# Altium schematic sheet Battery Management.SchDoc
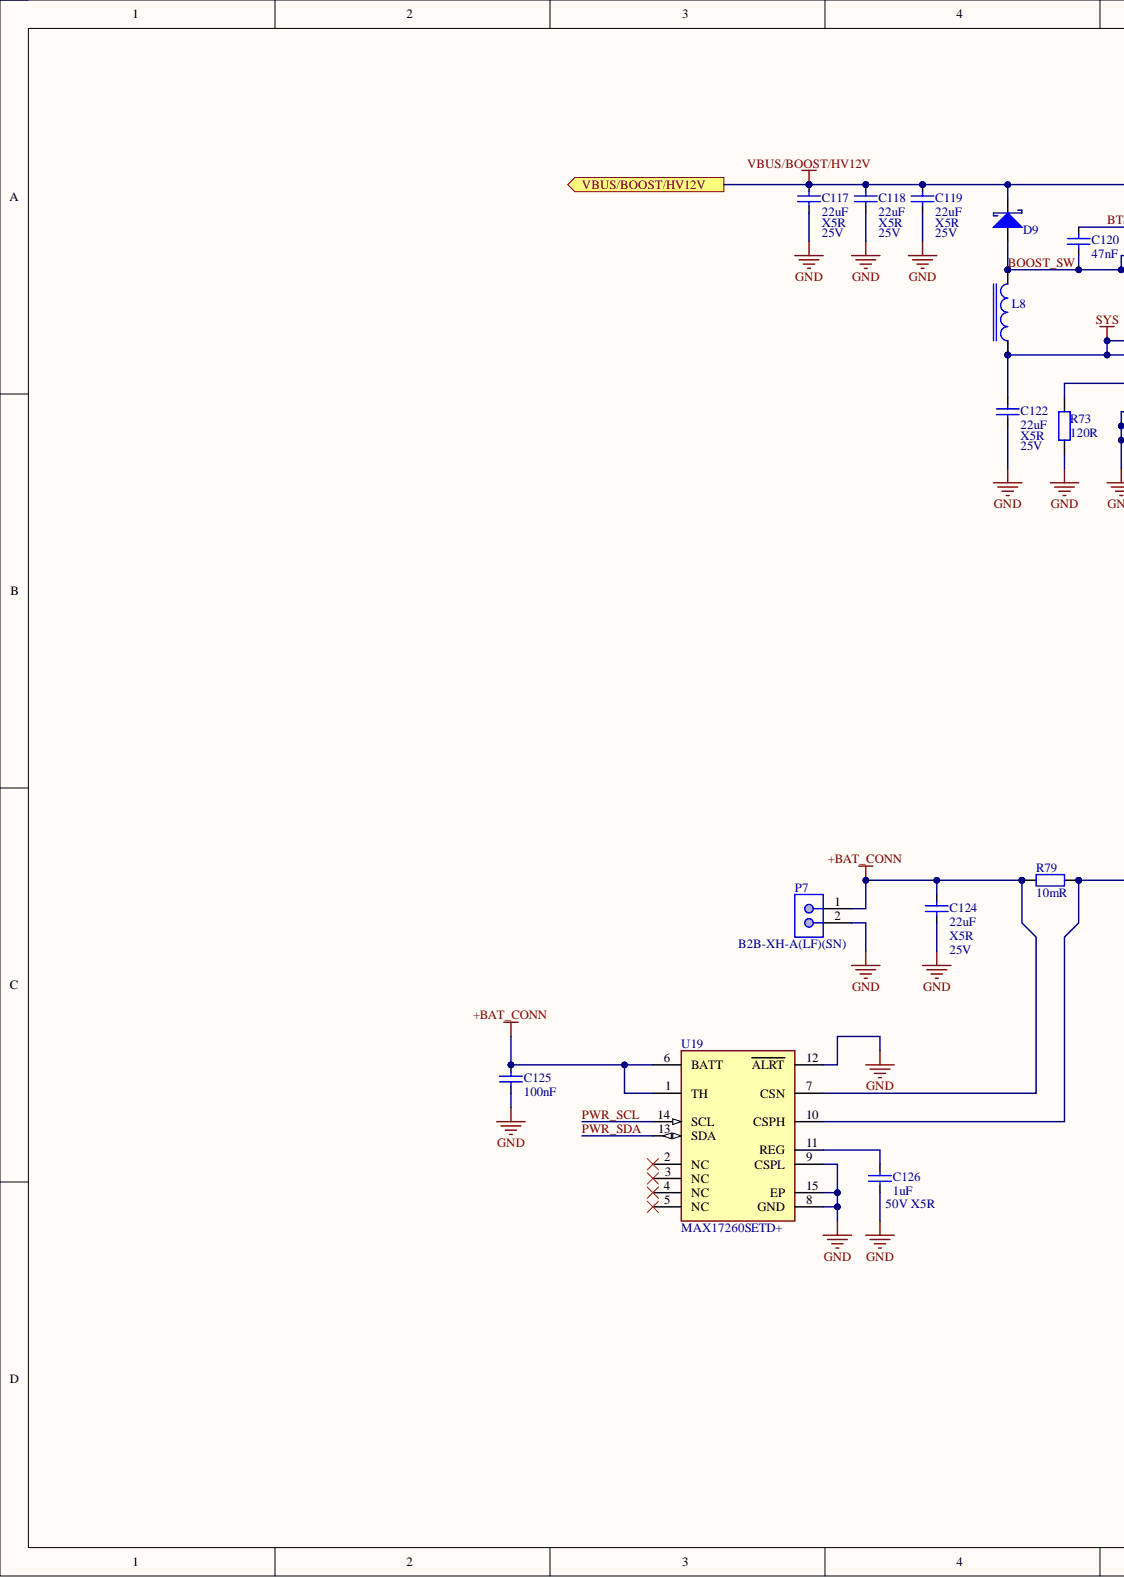
[diagram p0: Battery Management.SchDoc, left]
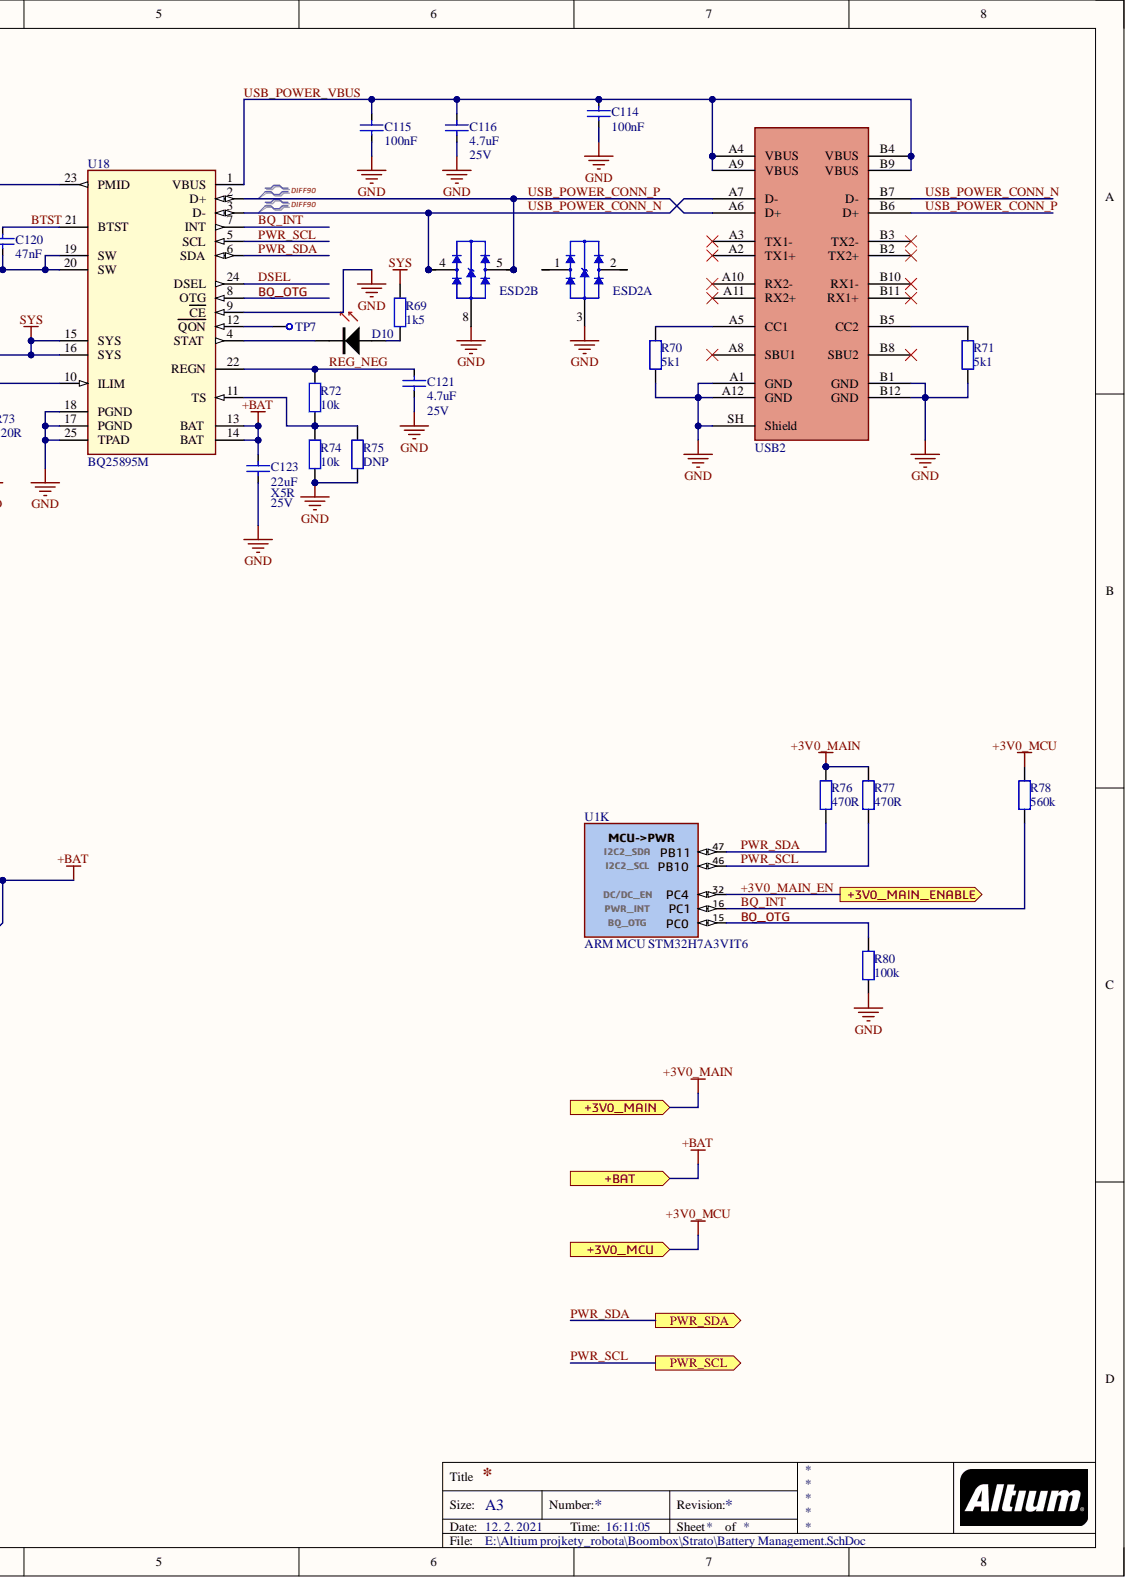
[diagram p1: Battery Management.SchDoc, right]

## Parts
C114  100nF  [CAPACITOR_0402_(1005)]
C115  100nF  [CAPACITOR_0402_(1005)]
C116  4.7uF  Voltage: 25V  [CAPACITOR_0603_(1608)]
C117  22uF  Dielectric: X5R  Voltage: 25V  [CAPACITOR_0805_(2012)]
C118  22uF  Dielectric: X5R  Voltage: 25V  [CAPACITOR_0805_(2012)]
C119  22uF  Dielectric: X5R  Voltage: 25V  [CAPACITOR_0805_(2012)]
C120  47nF  [CAPACITOR_0603_(1608)]
C121  4.7uF  Voltage: 25V  [CAPACITOR_0603_(1608)]
C122  22uF  Dielectric: X5R  Voltage: 25V  [CAPACITOR_0805_(2012)]
C123  22uF  Dielectric: X5R  Voltage: 25V  [CAPACITOR_0805_(2012)]
C124  22uF  Dielectric: X5R  Voltage: 25V  [CAPACITOR_0805_(2012)]
C125  100nF  [CAPACITOR_0402_(1005)]
C126  1uF  Dielectric: X5R  Voltage Rating: 50V X5R  Voltage Rating (DC): 50V  [CAPACITOR_0603_(1608)]
D9  NSR10F30NXT5G  [D_DSN2_(0502)]  (value not drawn)
D10  LED RED  [LED_0805_RED]  (value not drawn)
ESD2  ESD Suppressor  [DQA10]  (value not drawn)  (parts A,B)
L8  SRP7030CA-2R2M  [Power Inductor_SRP7030CA(SMD)  Bourns - Edited]  (value not drawn)
P7  B2B-XH-A(LF)(SN)  [JST-B2B-XH-A_V]
R69  1k5  [RESISTOR_0603_(1608)]
R70  5k1  [RESISTOR_0603_(1608)]
R71  5k1  [RESISTOR_0603_(1608)]
R72  10k  [RESISTOR_0603_(1608)]
R73  120R  [RESISTOR_0603_(1608)]
R74  10k  [RESISTOR_0603_(1608)]
R75  DNP  [RESISTOR_0603_(1608)]  (no BOM)
R76  470R  [RESISTOR_0603_(1608)]
R77  470R  [RESISTOR_0603_(1608)]
R78  560k  [RESISTOR_0603_(1608)]
R79  10mR  [RESISTOR_1206_(3216)]
R80  100k  [RESISTOR_0603_(1608)]
TP7  test point  [TP]  (value not drawn)  (no BOM)
U1  ARM MCU STM32H7A3VIT6  Manufacturer Part Number: STM32H7A3VIT6  [STM-LQFP100_N - Edited]  (part K)
U18  BQ25895M  [S-PWQFN-N24]
U19  MAX17260SETD+  [TDFN-EP-14]
USB2  DX07W024JA1R1000  [USB Type C DX07W024JA1]  (value not drawn)

## Ports
+3V0_MAIN, +3V0_MAIN_ENABLE, +3V0_MCU, +BAT, PWR_SCL, PWR_SDA, VBUS/BOOST/HV12V

## Nets
+BAT_CONN: C124.2 C125.1 P7.1 R79.2 U19.1(TH) U19.6(BATT) U19.7(CSN)
GND: C114.2 C115.2 C116.2 C117.1 C118.1 C119.1 C121.2 C122.1 C123.1 C124.1 C125.2 C126.2 ESD2.3 ESD2.8 P7.2 R70.2 R71.2 R73.1 R74.1 R75.1 R80.1 U18.9(CE) U18.17(PGND) U18.18(PGND) U18.25(TPAD) U19.8(GND) U19.9(CSPL) U19.12(ALRT) U19.15(EP) USB2.A1(GND) USB2.A12(GND) USB2.B1(GND) USB2.B12(GND) USB2.SH(Shield)
SYS: C122.2 L8.1 R69.1 U18.15(SYS) U18.16(SYS)
+3V0_MAIN: R76.2 R77.2 ; port +3V0_MAIN
+3V0_MAIN_EN: U1.32(PC4) ; port +3V0_MAIN_ENABLE
+3V0_MCU: R78.2 ; port +3V0_MCU
+BAT: C123.2 R79.1 U18.13(BAT) U18.14(BAT) U19.10(CSPH) ; port +BAT
BOOST_SW: C120.1 D9.2 L8.2 U18.19(SW) U18.20(SW)
BQ_INT: R78.1 U1.16(PC1) U18.7(INT)
BQ_OTG: R80.2 U1.15(PC0) U18.8(OTG)
BTST: C120.2 U18.21(BTST)
DSEL: U18.24(DSEL)
PWR_SCL: R77.1 U1.46(PB10) U18.5(SCL) U19.14(SCL) ; port PWR_SCL
PWR_SDA: R76.1 U1.47(PB11) U18.6(SDA) U19.13(SDA) ; port PWR_SDA
REG_NEG: C121.1 R72.2 U18.22(REGN)
USB_POWER_CONN_N: ESD2.4 ESD2.7 U18.3(D-) USB2.A7(D-) USB2.B7(D-)
USB_POWER_CONN_P: ESD2.5 ESD2.6 U18.2(D+) USB2.A6(D+) USB2.B6(D+)
USB_POWER_VBUS: C114.1 C115.1 C116.1 U18.1(VBUS) USB2.A4(VBUS) USB2.A9(VBUS) USB2.B4(VBUS) USB2.B9(VBUS)
VBUS/BOOST/HV12V: C117.2 C118.2 C119.2 D9.1 U18.23(PMID) ; port VBUS/BOOST/HV12V
NetC126_1: C126.1 U19.11(REG)
NetD10_A: D10.A R69.2
NetD10_K: D10.K U18.4(STAT)
NetESD2_1: ESD2.1 ESD2.10
NetESD2_2: ESD2.2 ESD2.9
NetR70_1: R70.1 USB2.A5(CC1)
NetR71_1: R71.1 USB2.B5(CC2)
NetR72_1: R72.1 R74.2 R75.2 U18.11(TS)
NetR73_2: R73.2 U18.10(ILIM)
NetTP7_1: TP7.1 U18.12(QON)

Not connected: U19 2(NC) 3(NC) 4(NC) 5(NC); USB2 A2(TX1+) A3(TX1-) A8(SBU1) A10(RX2-) A11(RX2+) B2(TX2+) B3(TX2-) B8(SBU2) B10(RX1-) B11(RX1+)

## Library data of the parts
C114 C115 C120 C125 = CAPACITOR: ESR: 0.28R; Voltage Rating: 10V; Component Kind: Standard; Description: CAPACITOR; Library Name: RLCFB.SchLib
  C114 C115 C125: Capacitance: 100nF
  C120: Capacitance: 47nF
C116 C121 = CAPACITOR: Manufacturer: Murata; Manufacturer Part Number 1: GRM188R61E475KE11D; Dielectric: X5R; ESR: 0.28R; Material: Ceramic; Tolerance: 10%; Capacitance: 4.7uF; Case Code (Imperial): 0603; Case Code (Metric): 1608; Case/Package: 0603; Component Kind: Standard; ComponentLink1Description: https://datasheet.ciiva.com/46444/1769893-46444982.pdf?src-supplier=Element14; ComponentLink1URL: https://datasheet.ciiva.com/46444/1769893-46444982.pdf?src-supplier=Element14; ComponentLink2Description: https://datasheet.ciiva.com/46444/1769893-46444983.pdf?src-supplier=Element14; ComponentLink2URL: https://datasheet.ciiva.com/46444/1769893-46444983.pdf?src-supplier=Element14; ComponentLink3Description: https://datasheet.ciiva.com/14891/partnumbering-e-01-14891102.pdf?src-supplier=Digi-Key; ComponentLink3URL: https://datasheet.ciiva.com/14891/partnumbering-e-01-14891102.pdf?src-supplier=Digi-Key; ComponentLink4Description: https://datasheet.ciiva.com/14891/partnumbering-e-01-14891103.pdf?src-supplier=Digi-Key; ComponentLink4URL: https://datasheet.ciiva.com/14891/partnumbering-e-01-14891103.pdf?src-supplier=Digi-Key; Depth: 0.8mm; Description: CAPACITOR; Height: 0.8mm; Lead Free: Lead Free; Length: 1.6mm; Max Operating Temperature: 85°C; Min Operating Temperature: -55°C; Mount: Surface Mount; Package Quantity: 4000; Packaging: Cut Tape; Pricing 1: 10=0,35700, 100=100,00000, 500=0,15900, 1000=0,12800, 2000=0,10200 (CHF); REACH SVHC: No SVHC; RoHS: Yes; Stock 1: 95119; Supplier 1: Farnell; Supplier Part Number 1: 2426959; Thickness: 0.031inch; Voltage Rating: 25V; Voltage Rating (DC): 25V; Width: 0.031inch; Library Name: RLCFB.SchLib
C117-C119 C122-C124 = CAPACITOR: Manufacturer: Murata; Manufacturer Part Number 1: GRM21BR61E226ME44L; Material: Ceramic; Tolerance: 20%; Capacitance: 22uF; Case Code (Imperial): 0805; Case Code (Metric): 2012; Case/Package: 0805; Component Kind: Standard; ComponentLink1Description: https://datasheet.ciiva.com/22787/1747471-22787265.pdf?src-supplier=Farnell; ComponentLink1URL: https://datasheet.ciiva.com/22787/1747471-22787265.pdf?src-supplier=Farnell; ComponentLink2Description: https://datasheet.ciiva.com/7719/1747471-7719095.pdf?src-supplier=Element14; ComponentLink2URL: https://datasheet.ciiva.com/7719/1747471-7719095.pdf?src-supplier=Element14; ComponentLink3Description: https://datasheet.ciiva.com/28584/1747471-28584199.pdf?src-supplier=Element14; ComponentLink3URL: https://datasheet.ciiva.com/28584/1747471-28584199.pdf?src-supplier=Element14; Composition: Ceramic; Depth: 1.25mm; Description: CAPACITOR; Height: 1.25mm; Lead Free: Lead Free; Length: 2mm; Max Operating Temperature: 85°C; Min Operating Temperature: -55°C; Mount: Surface Mount; Package Quantity: 3000; Packaging: Tape and Reel; Pricing 1: 5=0,42800, 50=0,25100, 250=0,20300, 500=0,15000, 1500=0,12800 (EUR); REACH SVHC: No SVHC; RoHS: Yes; Stock 1: 70400; Supplier 1: Farnell; Supplier Part Number 1: 1907510; Termination: SMD/SMT; Thickness: 0.057inch; Voltage Rating: 25V; Voltage Rating (DC): 25V; Weight: 0.000335oz; Width: 1.25mm; Library Name: RLCFB.SchLib
C126 = CAPACITOR: Manufacturer: Taiyo Yuden; Manufacturer Part Number 1: UMK107BJ105KA-T; ESR: 0.28R; Tolerance: 10%; Capacitance: 1uF; Case Code (Imperial): 0603; Case Code (Metric): 1608; Case/Package: 0603; Component Kind: Standard; ComponentLink1Description: https://datasheet.ciiva.com/5744/1697715-5744913.pdf?src-supplier=Farnell; ComponentLink1URL: https://datasheet.ciiva.com/5744/1697715-5744913.pdf?src-supplier=Farnell; ComponentLink2Description: https://datasheet.ciiva.com/11601/umk107bj105ka-t-ss-11601811.pdf?src-supplier=Avnet; ComponentLink2URL: https://datasheet.ciiva.com/11601/umk107bj105ka-t-ss-11601811.pdf?src-supplier=Avnet; ComponentLink3Description: https://datasheet.ciiva.com/15922/336umk107bj105ka-t-15922611.pdf?src-supplier=Onlinecomponents.com; ComponentLink3URL: https://datasheet.ciiva.com/15922/336umk107bj105ka-t-15922611.pdf?src-supplier=Onlinecomponents.com; ComponentLink4Description: https://datasheet.ciiva.com/134/umk107bj105ka-t-ss-134740.pdf?src-supplier=Digi-Key; ComponentLink4URL: https://datasheet.ciiva.com/134/umk107bj105ka-t-ss-134740.pdf?src-supplier=Digi-Key; ComponentLink5Description: https://datasheet.ciiva.com/30199/43umk107bj105ka-t-30199791.pdf?src-supplier=Onlinecomponents.com; ComponentLink5URL: https://datasheet.ciiva.com/30199/43umk107bj105ka-t-30199791.pdf?src-supplier=Onlinecomponents.com; ComponentLink6Description: https://datasheet.ciiva.com/22694/1697715-22694278.pdf?src-supplier=Element14; ComponentLink6URL: https://datasheet.ciiva.com/22694/1697715-22694278.pdf?src-supplier=Element14; ComponentLink7Description: https://datasheet.ciiva.com/7975/1697715-7975608.pdf?src-supplier=Element14; ComponentLink7URL: https://datasheet.ciiva.com/7975/1697715-7975608.pdf?src-supplier=Element14; ComponentLink8Description: https://datasheet.ciiva.com/7975/mlcc-all-e-7975898.pdf?src-supplier=Element14; ComponentLink8URL: https://datasheet.ciiva.com/7975/mlcc-all-e-7975898.pdf?src-supplier=Element14; ComponentLink9Description: https://datasheet.ciiva.com/5427/mlcc-all-e-541559-5427036.pdf?src-supplier=Mouser; ComponentLink9URL: https://datasheet.ciiva.com/5427/mlcc-all-e-541559-5427036.pdf?src-supplier=Mouser; Contact Plating: Nickel; Depth: 0.8mm; Description: CAPACITOR; Halogen Free: Halogen Free; Height: 0.8mm; Length: 1.6mm; Max Operating Temperature: 85°C; Min Operating Temperature: -55°C; Mount: Surface Mount; Number of Pins: 2; Package Quantity: 1; Packaging: Tape and Reel; Pricing 1: 10=0,14300, 100=0,06560, 500=0,04260, 1000=0,03940, 2000=0,03750, 4000=0,02830, 20000=0,02630 (EUR); Radiation Hardening: No; REACH SVHC: Unknown; RoHS: Yes; Stock 1: 372983; Supplier 1: Farnell; Supplier Part Number 1: 2112858; Termination: SMD/SMT; Thickness: 0.035inch; Width: 0.031inch; Library Name: RLCFB.SchLib
D9 = D_NSR10F30NXT5G: DIODE SCHOTTKY 30V 1A 2DSN; Manufacturer: ON Semiconductor; Element Configuration: Single; Forward Voltage: 470mV; Max Forward Surge Current (Ifsm): 18A; Max Power Dissipation: 1.47W; Max Reverse Current: 100uA; Max Reverse Leakage Current: 20uA; Power Dissipation: 548mW; Average Rectified Current: 1A; Case/Package: 0502; Category: Schottky Diodes; Component Kind: Standard; ComponentLink1Description: https://datasheet.ciiva.com/917/nsr10f30-d-917057.pdf?src-supplier=Digi-Key; ComponentLink1URL: https://datasheet.ciiva.com/917/nsr10f30-d-917057.pdf?src-supplier=Digi-Key; ComponentLink2Description: https://datasheet.ciiva.com/4713/nsr10f30-97625-4713884.pdf?src-supplier=Mouser; ComponentLink2URL: https://datasheet.ciiva.com/4713/nsr10f30-97625-4713884.pdf?src-supplier=Mouser; ComponentLink3Description: https://datasheet.ciiva.com/917/nsr10f30-d-917058.pdf?src-supplier=Digi-Key; ComponentLink3URL: https://datasheet.ciiva.com/917/nsr10f30-d-917058.pdf?src-supplier=Digi-Key; ComponentLink4Description: https://datasheet.ciiva.com/917/nsr10f30-d-917059.pdf?src-supplier=Digi-Key; ComponentLink4URL: https://datasheet.ciiva.com/917/nsr10f30-d-917059.pdf?src-supplier=Digi-Key; ComponentLink5Description: https://datasheet.ciiva.com/16474/nsr10f30-d-16474534.pdf?src-supplier=Future+Electronics; ComponentLink5URL: https://datasheet.ciiva.com/16474/nsr10f30-d-16474534.pdf?src-supplier=Future+Electronics; Forward Current: 1A; Lead Free: Lead Free; Library Name: BB1_SCH_Library.SchLib; Manufacturer Part Number: NSR10F30NXT5G; Max Operating Temperature: 150°C; Max Repetitive Reverse Voltage (Vrrm): 30V; Max Reverse Voltage (DC): 30V; Max Surge Current: 18A; Min Operating Temperature: -40°C; Number of Pins: 2; Package Quantity: 5000; Packaging: Tape and Reel; Peak Non-Repetitive Surge Current: 18A; Pricing 1: 1=0,44000, 10=0,32200, 100=0,18700, 1000=0,12200, 5000=0,11200, 10000=0,10300 (USD); Radiation Hardening: No; RoHS: Yes; Stock 1: 10180; Supplier 1: Mouser; Supplier Part Number 1: 863-NSR10F30NXT5G
D10 = LED RED 0805: KPT-2012EC -  LED, Low Power, Red, SMD, 0805, 2 mA, 2 V, 617 nm; Manufacturer: Kingbright; Dominant Wavelength: 617nm; Forward Current: 20mA; Forward Voltage: 2V; Lens Color: Clear; Lens Style: Diffused; Luminous Intensity: 8mcd; Number of LEDs: 1; Viewing Angle: 120°; Case/Package: 2012; Color: Red; Component Kind: Standard; ComponentLink1Description: https://datasheet.ciiva.com/8060/1563702-8060626.pdf?src-supplier=Element14; ComponentLink1URL: https://datasheet.ciiva.com/8060/1563702-8060626.pdf?src-supplier=Element14; Library Name: Source.SchLib; Manufacturer Part Number 1: KPT-2012EC; Mount: Surface Mount; Number of Pins: 2; Packaging: Cut Tape; Pricing 1: 5=0,15600, 50=0,14200, 100=0,09300, 500=0,07860, 1000=0,06180 (EUR); Radiation Hardening: No; REACH SVHC: No SVHC; RoHS: Yes; Stock 1: 30789; Supplier 1: Farnell; Supplier Part Number 1: 2099236; Wavelength: 617nm
ESD2 = D_TPD4E05U06DQAR: TEXAS INSTRUMENTS - ESD Protection Device, 14 V, USON, 10 Pins, 5.5 V, TPD4E Series; Breakdown Voltage: 6.5V; Capacitance: 0.5pF; Clamping Voltage: 14V; Direction: Unidirectional; Interface: LVDS; Leakage Current: 10nA; Max Power Dissipation: 40W; Number of Bidirectional Channels: 4; Operating Supply Voltage: 5.5V; Peak Pulse Current: 5A; Power Line Protection: Yes; Component Kind: Standard; ComponentLink1Description: https://datasheet.ciiva.com/15063/tpd4e05u06-15063653.pdf?src-supplier=Digi-Key; ComponentLink1URL: https://datasheet.ciiva.com/15063/tpd4e05u06-15063653.pdf?src-supplier=Digi-Key; ComponentLink2Description: https://datasheet.ciiva.com/26918/getdatasheetpartid-716763-26918024.pdf?src-supplier=Verical; ComponentLink2URL: https://datasheet.ciiva.com/26918/getdatasheetpartid-716763-26918024.pdf?src-supplier=Verical; ComponentLink3Description: https://datasheet.ciiva.com/24119/slvsbo7f-316827-24119436.pdf?src-supplier=Mouser; ComponentLink3URL: https://datasheet.ciiva.com/24119/slvsbo7f-316827-24119436.pdf?src-supplier=Mouser; ComponentLink4Description: https://datasheet.ciiva.com/15063/tpd4e05u06-15063654.pdf?src-supplier=Digi-Key; ComponentLink4URL: https://datasheet.ciiva.com/15063/tpd4e05u06-15063654.pdf?src-supplier=Digi-Key; ComponentLink5Description: https://datasheet.ciiva.com/29883/tpd4e05u06-29883798.pdf?src-supplier=Avnet; ComponentLink5URL: https://datasheet.ciiva.com/29883/tpd4e05u06-29883798.pdf?src-supplier=Avnet; ComponentLink6Description: https://datasheet.ciiva.com/15063/tpd4e05u06-15063655.pdf?src-supplier=Digi-Key; ComponentLink6URL: https://datasheet.ciiva.com/15063/tpd4e05u06-15063655.pdf?src-supplier=Digi-Key; Contact Plating: Gold; Depth: 1.1mm; Lead Free: Lead Free; Length: 2.6mm; Library Name: Source.SchLib; Manufacturer: Texas Instruments; Manufacturer Part Number 1: TPD4E05U06DQAR; Max Operating Temperature: 125°C; Min Breakdown Voltage: 6.5V; Min Operating Temperature: -40°C; Mount: Surface Mount; Number of Channels: 4; Number of Pins: 10; Package Quantity: 3000; Packaging: Tape and Reel; Peak Pulse Power: 40W; Polarity: Unidirectional; Pricing 1: 1=0,61000, 10=0,50000, 50=0,50000, 100=0,32400, 1000=0,26000, 2000=0,23500, 3000=0,21900, 9000=0,21100, 10000=0,21100, 24000=0,20300, 48000=0,19500 (USD); Radiation Hardening: No; REACH SVHC: No SVHC; Reverse Standoff Voltage: 5.5V; RoHS: Yes; Stock 1: 7182; Supplier 1: Mouser; Supplier Part Number 1: 595-TPD4E05U06DQAR; Termination: SMD/SMT; Working Voltage: 5.5V
L8 = IND_SRP7030CA-2R2M: Fixed Inductors Ind,7.8x7.6x2.9mm,2.2uH 20%,13A,Shd,SMD; Manufacturer: Bourns; Component Kind: Standard; Library Name: BB1_SCH_Library.SchLib; Manufacturer Part Number: SRP7030CA-2R2M; Pricing 1: 1=1,53000, 10=1,48000, 25=1,43000, 50=1,39000, 100=1,35000, 250=1,16000 (USD); RoHS: No; Stock 1: 197; Supplier 1: Mouser; Supplier Part Number 1: 652-SRP7030CA-2R2M
P7 = Batt Connector B2B-XH-A: Conn Shrouded Header (4 Sides) HDR 2 POS 2.5mm Solder ST Thru-Hole Box; Manufacturer: JST; Connector Type: Male Pin; Contact Resistance: 20mR; Current Rating: 3A; Insulation Resistance: 1GR; Max Voltage Rating (AC): 250V; Number of Contacts: 2; Wire/Cable Gauge: 22AWG; Category: FFC / FPC; Color: Natural; Component Kind: Standard; ComponentLink1Description: https://datasheet.ciiva.com/26894/getdatasheetpartid-640357-26894434.pdf?src-supplier=Verical; ComponentLink1URL: https://datasheet.ciiva.com/26894/getdatasheetpartid-640357-26894434.pdf?src-supplier=Verical; ComponentLink2Description: https://datasheet.ciiva.com/11746/0900766b8082653e-11746979.pdf?src-supplier=RSComponents; ComponentLink2URL: https://datasheet.ciiva.com/11746/0900766b8082653e-11746979.pdf?src-supplier=RSComponents; ComponentLink3Description: https://datasheet.ciiva.com/26894/getdatasheetpartid-385291-26894760.pdf?src-supplier=Verical; ComponentLink3URL: https://datasheet.ciiva.com/26894/getdatasheetpartid-385291-26894760.pdf?src-supplier=Verical; ComponentLink4Description: https://datasheet.ciiva.com/8052/5448-8052024.pdf?src-supplier=Element14; ComponentLink4URL: https://datasheet.ciiva.com/8052/5448-8052024.pdf?src-supplier=Element14; ComponentLink5Description: https://datasheet.ciiva.com/20207/523653-20207167.pdf?src-supplier=Rapid+Electronics; ComponentLink5URL: https://datasheet.ciiva.com/20207/523653-20207167.pdf?src-supplier=Rapid+Electronics; Contact Material: Brass; Contact Plating: Tin,Copper,Lead; Depth: 5.75mm; Fastening Type: Detent; Housing Color: White; Housing Material: Polyamide; Lead Free: Lead Free; Lead Pitch: 2.5mm; Length: 7.4mm; Library Name: BB1_SCH_Library.SchLib; Manufacturer Part Number: B2B-XH-A(LF)(SN); Max Current Rating: 3A; Max Operating Temperature: 85°C; Max Voltage Rating (DC): 250V; Min Operating Temperature: -25°C; Mount: Through Hole; Number of Positions: 2; Number of Rows: 1; Orientation: Straight; Packaging: Bulk; Pitch: 2.5mm; Pricing 1: 100=0,06890, 1000=0,06030, 2500=0,05600, 5000=0,05160, 10000=0,05030, 20000=0,04930 (EUR); REACH SVHC: No SVHC; RoHS: Yes; Stock 1: 67303; Supplier 1: Farnell; Supplier Part Number 1: 1516276; Termination: Solder; Voltage Rating: 250V; Voltage Rating (AC): 250V
R69-R78 R80 = RESISTOR: Power Rating: 500mW; Description: RESISTOR; Library Name: RLCFB.SchLib
  R69: Component Kind: Standard; Resistance: 1k5
  R70 R71: Component Kind: Standard; Resistance: 5k1
  R72 R74: Component Kind: Standard; Resistance: 10k
  R73: Component Kind: Standard; Resistance: 120R
  R75: Resistance: DNP
  R76 R77: Component Kind: Standard; Resistance: 470R
  R78: Component Kind: Standard; Resistance: 560k
  R80: Component Kind: Standard; Resistance: 100k
R79 = RESISTOR: Manufacturer: TT Welwyn; Manufacturer Part Number: LRMAM1206-R01FT5; Max Power Dissipation: 1W; Temperature Coefficient: 100ppm/°C; Tolerance: 1%; Case Code (Imperial): 1206; Case Code (Metric): 3216; Case/Package: 1206; Component Kind: Standard; ComponentLink1Description: https://datasheet.ciiva.com/7932/1518406-7932008.pdf?src-supplier=Element14; ComponentLink1URL: https://datasheet.ciiva.com/7932/1518406-7932008.pdf?src-supplier=Element14; ComponentLink2Description: https://datasheet.ciiva.com/14982/lrma-14982158.pdf?src-supplier=Digi-Key; ComponentLink2URL: https://datasheet.ciiva.com/14982/lrma-14982158.pdf?src-supplier=Digi-Key; ComponentLink3Description: https://datasheet.ciiva.com/14982/lrma-14982159.pdf?src-supplier=Digi-Key; ComponentLink3URL: https://datasheet.ciiva.com/14982/lrma-14982159.pdf?src-supplier=Digi-Key; Composition: Metal Element; Depth: 1.6mm; Description: RESISTOR; Features: Automotive AEC-Q200; Height: 0.031inch; Length: 3.2mm; Max Operating Temperature: 170°C; Military Standard: Not; Min Operating Temperature: -55°C; Number of Terminations: 2; Package Quantity: 5000; Packaging: Tape and Reel; Power Rating: 1W; Pricing 1: 10=0,32600, 100=0,16300, 500=0,13200, 1000=0,12600, 2500=0,12000 (EUR); REACH SVHC: No SVHC; Resistance: 10mR; RoHS: Yes; Stock 1: 775; Supplier 1: Farnell; Supplier Part Number 1: 2079442; Termination: SMD/SMT; Width: 0.063inch; Library Name: RLCFB.SchLib
U1 = STM32H7A3VIT6_LQFP100: STM32H7A3VIT6 -  32-bit Arm® Cortex®-M7 280 MHz MCUs, up to 2-Mbyte Flash memory, 1.4Mbyte RAM, 46 com. and analog interfaces; Manufacturer: STMicroelectronics; Category: Microcontrollers; Component Kind: Standard; ComponentLink1Description: Datasheet; ComponentLink1URL: https://www.st.com/resource/en/datasheet/stm32h7a3vi.pdf; Library Name: BB1_SCH_Library.SchLib; RoHS: No; Supplier 1: Mouser; Supplier Part Number 1: 511-STM32H7A3VIT6
U18 = BQ25895M: IC NVDC CHARGER I2C 24WQFN; Manufacturer: Texas Instruments; Manufacturer Part Number: BQ25895MRTWR; Fault Protection: Over Temperature; Interface: I2C,USB; Max Charge Current: 5A; Max Supply Voltage: 14V; Number of Cells: 1; Output Voltage: 5.5V; Category: Battery Management; Component Kind: Standard; ComponentLink1Description: http://www.ti.com/lit/ds/symlink/bq25895m.pdf?src-supplier=Digi-Key; ComponentLink1URL: http://www.ti.com/lit/ds/symlink/bq25895m.pdf?src-supplier=Digi-Key; ComponentLink2Description: http://www.ti.com/general/docs/lit/getliterature.tsp?genericPartNumber=BQ25895M&amp;&amp;fileType=pdf&src-supplier=Avnet+Asia; ComponentLink2URL: http://www.ti.com/general/docs/lit/getliterature.tsp?genericPartNumber=BQ25895M&amp;&amp;fileType=pdf&src-supplier=Avnet+Asia; ComponentLink3Description: http://www.ti.com/general/docs/lit/getliterature.tsp?genericPartNumber=BQ25895M&&fileType=pdf&src-supplier=Avnet; ComponentLink3URL: http://www.ti.com/general/docs/lit/getliterature.tsp?genericPartNumber=BQ25895M&&fileType=pdf&src-supplier=Avnet; Contact Plating: Gold; Lead Free: Lead Free; Library Name: BB1_SCH_Library.SchLib; Max Operating Temperature: 85°C; Min Operating Temperature: -40°C; Mount: Surface Mount; Number of Pins: 24; Output Current: 5A; Packaging: Cut Tape; Pricing 1: 1=3,27000, 10=2,94000, 25=2,78000, 100=2,28000, 250=2,05000, 500=1,98000 (USD); RoHS: Yes; Stock 1: 3332; Supplier 1: Mouser; Supplier Part Number 1: 595-BQ25895MRTWR
U19 = Battery Fuel Gauge MAX17260: Fuel Gauge Li+/LiFePO4 1-Cell 2-Wire Interface 5.1uA 0.4V 14-Pin TDFN-EP Tube; Manufacturer: Maxim; Category: Battery Management; Component Kind: Standard; Library Name: BB1_SCH_Library.SchLib; Manufacturer Part Number: MAX17260SETD+; Pricing 1: 1=2,28000, 10=2,21000, 25=1,53000, 50=1,36000, 100=1,32000, 250=1,28000 (USD); RoHS: No; Stock 1: 2571; Supplier 1: Mouser; Supplier Part Number 1: 700-MAX17260SETD+
USB2 = USB C JAE: CONN RCPT USB3.1 TYPEC 24POS SMD; Manufacturer: JAE Electronics; Connector Type: USB; Current Rating: 6.25A; Gender: Receptacle; Mating Cycles: 10000; Number of Contacts: 24; Number of Ports: 1; Ratings: Waterproof; Shielding: Shielded; Category: Connectors; Component Kind: Standard; ComponentLink1Description: http://www.jae.com/z-en/pdf_download_exec.cfm?param=SJ119582.pdf&src-supplier=Digi-Key; ComponentLink1URL: http://www.jae.com/z-en/pdf_download_exec.cfm?param=SJ119582.pdf&src-supplier=Digi-Key; ComponentLink2Description: http://www.jae.com/z-en/pdf_download_exec.cfm?param=SJ119583.pdf&src-supplier=Digi-Key; ComponentLink2URL: http://www.jae.com/z-en/pdf_download_exec.cfm?param=SJ119583.pdf&src-supplier=Digi-Key; Contact Plating: Gold; Insulation Color: Black; Library Name: BB1_SCH_Library.SchLib; Manufacturer Part Number: DX07W024JA1R1000; Max Operating Temperature: 80°C; Min Operating Temperature: -30°C; Mount: Surface Mount,Edge; Packaging: Cut Tape; Pricing 1: 1=3,75000, 10=3,15000, 100=3,00000, 250=2,46000, 500=2,39000, 1000=2,04000 (USD); RoHS: No; Supplier 1: Mouser; Supplier Part Number 1: 656-DX07W024JA1R1000; Termination: Solder
TP7 = test point: Library Name: BB1_SCH_Library.SchLib
